# Altium schematic sheet lv_signal_air.SchDoc(lv_signal_air)
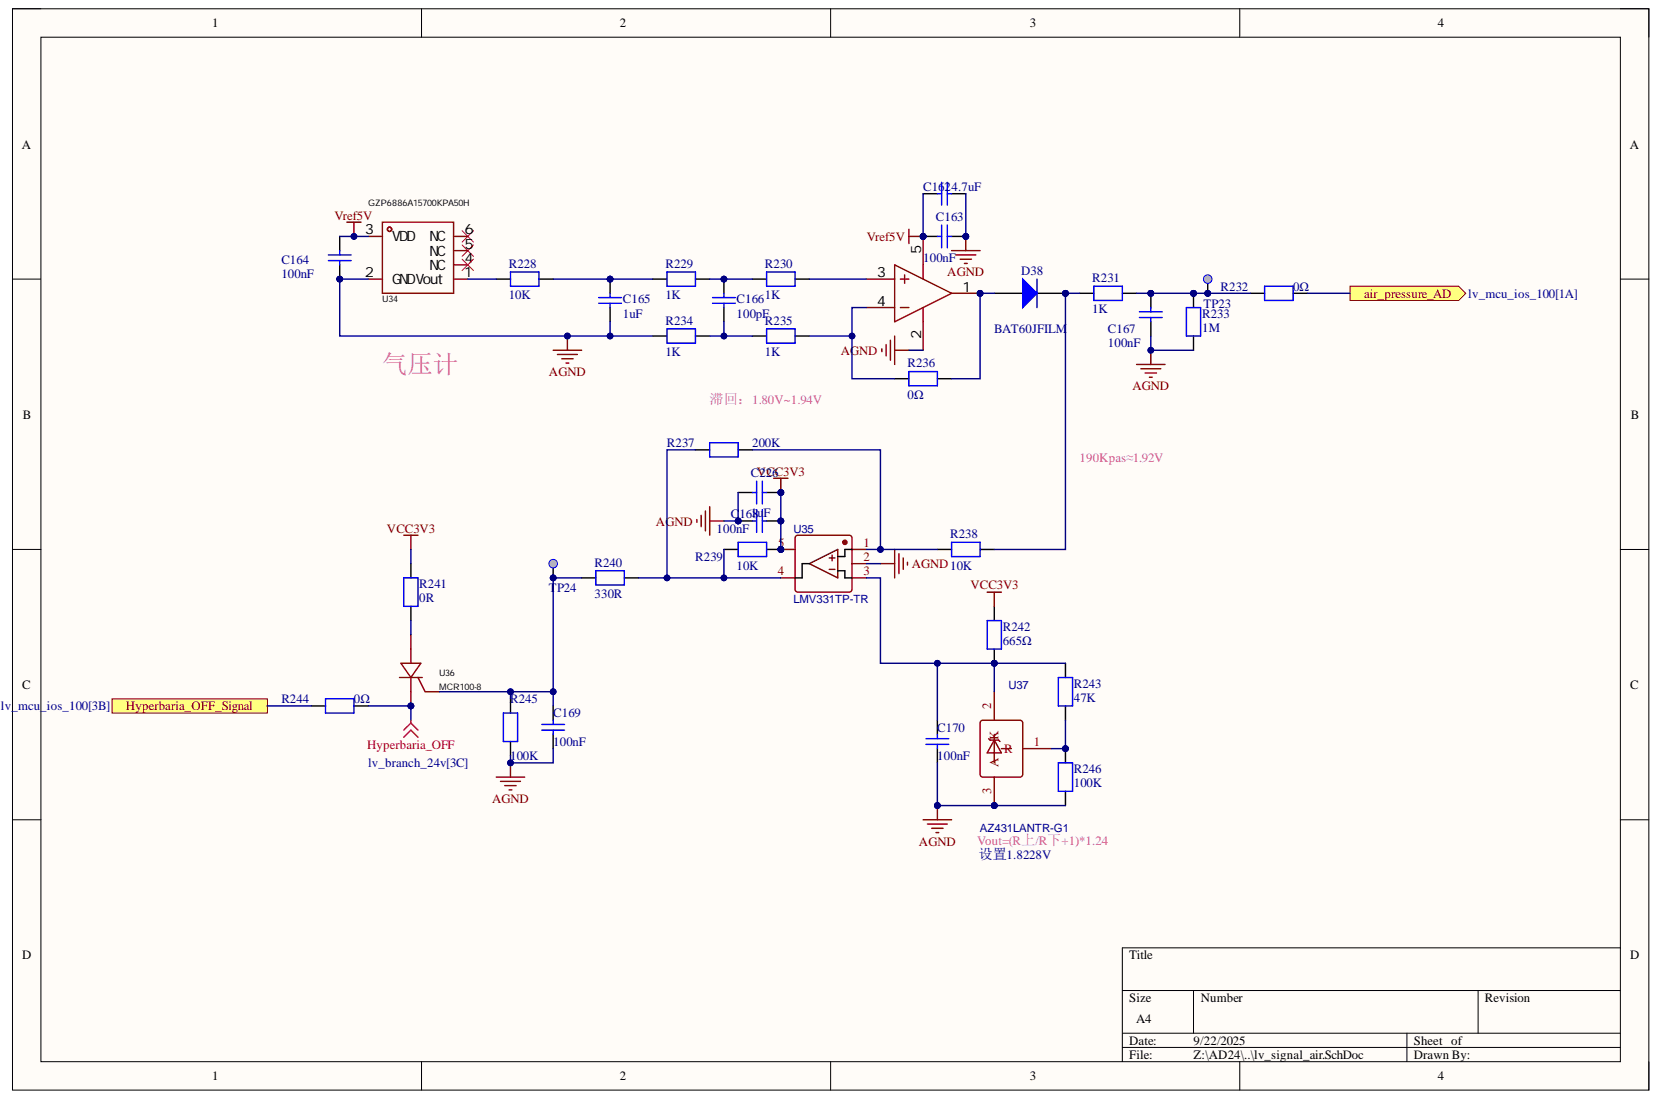
[diagram p0: lv_signal_air.SchDoc]

## Parts
C162  4.7uF  [C0603]
C163  100nF  [C0402]
C164  100nF  [C0402]
C165  1uF  [C0603]
C166  100pF  [C0402]
C167  100nF  [C0402]
C168  100nF  [C0402]
C169  100nF  [C0402]
C170  100nF  [C0402]
C226  value 1uF  [C0603]
D38  BAT60JFILM  [DIO_SOD323]
R228  10K  [R0402]
R229  1K  [R0402]
R230  1K  [R0402]
R231  1K  [R0402]
R232  0Ω  [R0402]
R233  1M  [R0402]
R234  1K  [R0402]
R235  1K  [R0402]
R236  0Ω  [R0402]
R237  200K  [R0402]
R238  10K  [R0402]
R239  10K  [R0402]
R240  330R  [R0402]
R241  0R  [R0402]
R242  665Ω  [R0402]
R243  47K  [R0402]
R244  0Ω  [R0402]
R245  100K  [R0402]
R246  100K  [R0402]
TP23  Test Point  [TP_SMD_1MM]  (value not drawn)  (no BOM)
TP24  Test Point  [TP_SMD_1MM]  (value not drawn)  (no BOM)
U33  TLV271CDBVR  [SOT-23-5_L3.0-W1.7-P0.95-LS2.8-BR]  (value not drawn)
U34  GZP6886A15700KPA50H  [SENSOR-TH_SENCOCH_GZP6873A]
U35  LMV331TP-TR  [SOT-23-5_L3.0-W1.7-P0.95-LS2.8-BR]
U36  MCR100-8  [SOT-23-3_L2.9-W1.3-P1.90-LS2.4-BR-CW]
U37  AZ431LANTR-G1  [SOT-23-3_L2.9-W1.6-P1.90-LS2.8-BR]

## Ports
air_pressure_AD, Hyperbaria_OFF_Signal

## Nets
AGND: C162.1 C163.2 C164.1 C165.2 C167.1 C168.2 C169.2 C170.2 C226.1 R233.1 R234.1 R245.1 R246.1 U33.2 U34.2(GND) U35.2 U37.3
Hyperbaria_OFF: R244.1 U36.1
VCC3V3: C168.1 C226.2 R239.2 R241.1 R242.2 U35.5
Vref5V: C162.2 C163.1 C164.2 U33.5 U34.3(VDD)
air_pressure_AD: R232.1 ; port air_pressure_AD
Hyperbaria_OFF_Signal: R244.2 ; port Hyperbaria_OFF_Signal
NetC165_1: C165.1 R228.2 R229.1
NetC166_1: C166.1 R229.2 R230.1
NetC166_2: C166.2 R234.2 R235.1
NetC167_2: C167.2 R231.2 R232.2 R233.2 TP23.1
NetC169_1: C169.1 R240.1 R245.2 TP24.1 U36.3
NetC170_1: C170.1 R242.1 R243.2 U35.3 U37.2
NetD38_1: D38.1 R236.1 U33.1
NetD38_2: D38.2 R231.1 R238.1
NetR228_1: R228.1 U34.1(Vout)
NetR230_2: R230.2 U33.3
NetR235_2: R235.2 R236.2 U33.4
NetR237_1: R237.1 R238.2 U35.1
NetR237_2: R237.2 R239.1 R240.2 U35.4
NetR241_2: R241.2 U36.2
NetR243_1: R243.1 R246.2 U37.1

Not connected: U34 4(NC) 5(NC) 6(NC)

## Library data of the parts
C162 = Capacitor_1: 16V, X7S, 10%; Internal_Part_Number: MCD-00009; Manufacturer: muRata; Manufacturer_Part_Number: GRM188C71C475KE21D; Component Kind: Standard; ComponentLink1Description: Yageo_Capacitor_X5R-series.pdf; ComponentLink1URL: http://tunmcdsvn.tun.st.com/Component_Datasheet_Library/Trunk/Capacitors/Yageo_Multilayer_capacitor_X5R_series_100pF_to_100uF; Library Name: HiPin_Library_AD.IntLib; Package: C0603; Value: 4.7uF
C163 C164 C167 = Capacitor: 50V, 5%, X7R; Manufacturer: muRata; Manufacturer_Part_Number: GRM155R71H104KE14D; Component Kind: Standard; ComponentLink1Description: Datasheet; ComponentLink1URL: http://tunmcdsvn.tun.st.com/Component_Datasheet_Library/Trunk/Capacitors/Yageo_Capacitor_NP0_16V-to-50V; Library Name: HiPin_Library_AD.IntLib
C165 = Capacitor_1: 50V, X5R, 10%; Manufacturer: muRata; Manufacturer_Part_Number: GRM188R61H105KAALD; Component Kind: Standard; ComponentLink1Description: Yageo_Capacitor_X5R-series.pdf; ComponentLink1URL: http://tunmcdsvn.tun.st.com/Component_Datasheet_Library/Trunk/Capacitors/Yageo_Multilayer_capacitor_X5R_series_100pF_to_100uF; Library Name: HiPin_Library_AD.IntLib
C166 = Capacitor: 50V, 5%, C0G; Manufacturer: muRata; Manufacturer_Part_Number: GRM1555C1H101JA01D; Component Kind: Standard; ComponentLink1Description: Datasheet; ComponentLink1URL: http://tunmcdsvn.tun.st.com/Component_Datasheet_Library/Trunk/Capacitors/Yageo_Capacitor_NP0_16V-to-50V; Library Name: HiPin_Library_AD.IntLib
C168-C170 = Capacitor: 50V, 10%, X7R; Internal_Part_Number: MCD-00003; Manufacturer: muRata; Manufacturer_Part_Number: GRM155R71H104KE14D; Component Kind: Standard; ComponentLink1Description: Datasheet; ComponentLink1URL: http://tunmcdsvn.tun.st.com/Component_Datasheet_Library/Trunk/Capacitors/Yageo_Capacitor_NP0_16V-to-50V; Library Name: HiPin_Library_AD.IntLib; Package: C0402; Value: 100nF
C226 = MCD-00010: Capacitor, MLCC, 1uF, 16V, X5R, 10%, 0603, T=0,8mm; Manufacturer: YAGEO; Manufacturer_Part_Number: CC0603KRX5R7BB105; Database Table Name: MCD_PARTS$; Initial_Board_Reference: MB1274; Provided_By: Subcon; Component Kind: Standard; ComponentLink1Description: Yageo_Capacitor_X5R-series.pdf; ComponentLink1URL: http://tunmcdsvn.tun.st.com/Component_Datasheet_Library/Trunk/Capacitors/Yageo_Multilayer_capacitor_X5R_series_100pF_to_100uF; ECCN_EU: n/a; ECCN_US: n/a; Internal_Part_Number: MCD-00010; Library Name: MCD_PARTS_database.DbLib; Package: C0603; Part_Type: Capacitor; Status: Released
D38 = BAT60JFILM: Internal_Part_Number: MCD-00034; Manufacturer: STMICROELECTRONICS; Part_Type: Diode; ECCN_EU: NEC; ECCN_US: EAR99; Initial_Board_Reference: MB1274; Provided_By: Subcon; Component Kind: Standard; ComponentLink1Description: STM_Diode_BAT60JFILM-SOD323.pdf; ComponentLink1URL: http://tunmcdsvn.tun.st.com/Component_Datasheet_Library/Trunk/Diodes/STM_Diode_BAT60JFILM-SOD323; Description: BAT60JFILM; Library Name: HiPin_Library_AD.IntLib; Manufacturer_Part_Number: BAT60JFILM; Status: Released; Value: BAT60JFILM
R228 R238 R239 = RES: 1%; Component Kind: Standard; Library Name: HiPin_Library_AD.IntLib; Value: 10K
R229-R237 R240 R241 R244 R245 = RES: 1%; Value: 10K; Component Kind: Standard; Library Name: HiPin_Library_AD.IntLib
R242 R243 R246 = RES: Resistor; Component Kind: Standard; Library Name: HiPin_Library_AD.IntLib
TP23 TP24 = MCD-00074: Test Point 1mm; Part_Type: Mechanical; Database Table Name: MCD_PARTS$; ComponentLink1Description: Datasheet; ComponentLink1URL: http://na; ECCN_EU: n/a; ECCN_US: n/a; Internal_Part_Number: MCD-00074; Library Name: MCD_PARTS_database.DbLib; Manufacturer: n/a; Manufacturer_Part_Number: n/a; Provided_By: n/a; Status: Released
U33 = TLV271CDBVR: Manufacturer: TI(德州仪器); Add into BOM: yes; Current - Input Bias(Ib): 1pA; Gain Bandwidth Product(GBP): 3MHz; Number of Circuits: 单路; Output Current: 8mA; Slew Rate(SR): 2.6V/us; Supply Current: 625uA; Component Kind: Standard; Convert to PCB: yes; Datasheet: https://www.ti.com/cn/lit/gpn/tlv271; Device: TLV271CDBVR; JLCPCB Part Class: Extended Part; LCSC Part Name: TLV271CDBVR; Library Name: HiPin_Library_AD.IntLib; Manufacturer Part: TLV271CDBVR; Operating Temperature: 0℃~+70℃; Output Type: -; Rail to Rail: -; Supplier: LCSC; Supplier Footprint: SOT-23-5; Supplier Part: C131948; Symbol: TLV271CDBVR
U34 = GZP6886A15700KPA50H: Manufacturer: Sencoch(芯感智); Add into BOM: yes; Component Kind: Standard; Convert to PCB: yes; Datasheet: https://atta.szlcsc.com/upload/public/pdf/source/20231019/448B311B7B3976EF540842F506B586E7.pdf; Device: GZP6886A15700KPA50H; JLCPCB Part Class: Extended Part; LCSC Part Name: 气压传感器; Library Name: HiPin_Library_AD.IntLib; Manufacturer Part: GZP6886A15700KPA50H; Supplier: LCSC; Supplier Footprint: 插件; Supplier Part: C18905975; Symbol: GZP6886A15700KPA50H
U35 = LMV331TP-TR: 比较器; BOM_Manufacturer: 3PEAK; Contributor: LCSC; BOM_JLCPCB Part Class: Extended Part; BOM_Manufacturer Part: LMV331TP-TR; BOM_Supplier: LCSC; BOM_Supplier Part: C108095; Component Kind: Standard; Library Name: HiPin_Library_AD.IntLib; spicePre: U; spiceSymbolName: LMV331TP-TR
U36 = MCR100-8_C5184437: Manufacturer: HXY MOSFET(华轩阳电子); Add into BOM: yes; Average Gate Power Dissipation (PG(AV)): 100mW; Gate Trigger   Voltage (Vgt): 800mV; Gate Trigger Current(Igt): 60uA; Holding Current (Ih): 5mA; Peak non-repetitive surge current (Itsm@f): 8A; Peak Repetitive Off?State Voltage (Vdrm): 600V; RMS On-State Current(It (rms)): 800mA; SCR Type: 单向可控硅; Voltage - On State (Vtm): 1.7V; Component Kind: Standard; Convert to PCB: yes; Datasheet: https://atta.szlcsc.com/upload/public/pdf/source/20240902/7358CD860A43FFBE3B53FCDEB35A6F8C.pdf; Device: MCR100-8_C5184437; JLCPCB Part Class: Extended Part; LCSC Part Name: 单向 Vgt: 800mV IT:0.8A Vdrm: 600V; Library Name: HiPin_Library_AD.IntLib; Manufacturer Part: MCR100-8; Operating Temperature: -; PCB3D: SOT-23-3_L2.9-W1.3-P1.90-LS2.4-BR-CW; Supplier: LCSC; Supplier Footprint: SOT-23; Supplier Part: C5184437; Symbol: MCR100-8_C5184437
U37 = TL431: BOM_Manufacturer: JSMSEMI; Contributor: LCSC; BOM_JLCPCB Part Class: Extended Part; BOM_Manufacturer Part: TL431; BOM_Supplier: LCSC; BOM_Supplier Part: C963380; Component Kind: Standard; Library Name: HiPin_Library_AD.IntLib; spicePre: U; spiceSymbolName: TL431_C963380
